# Altium schematic sheet 08)Battery_Charger.SchDoc(08)Battery_Charger)
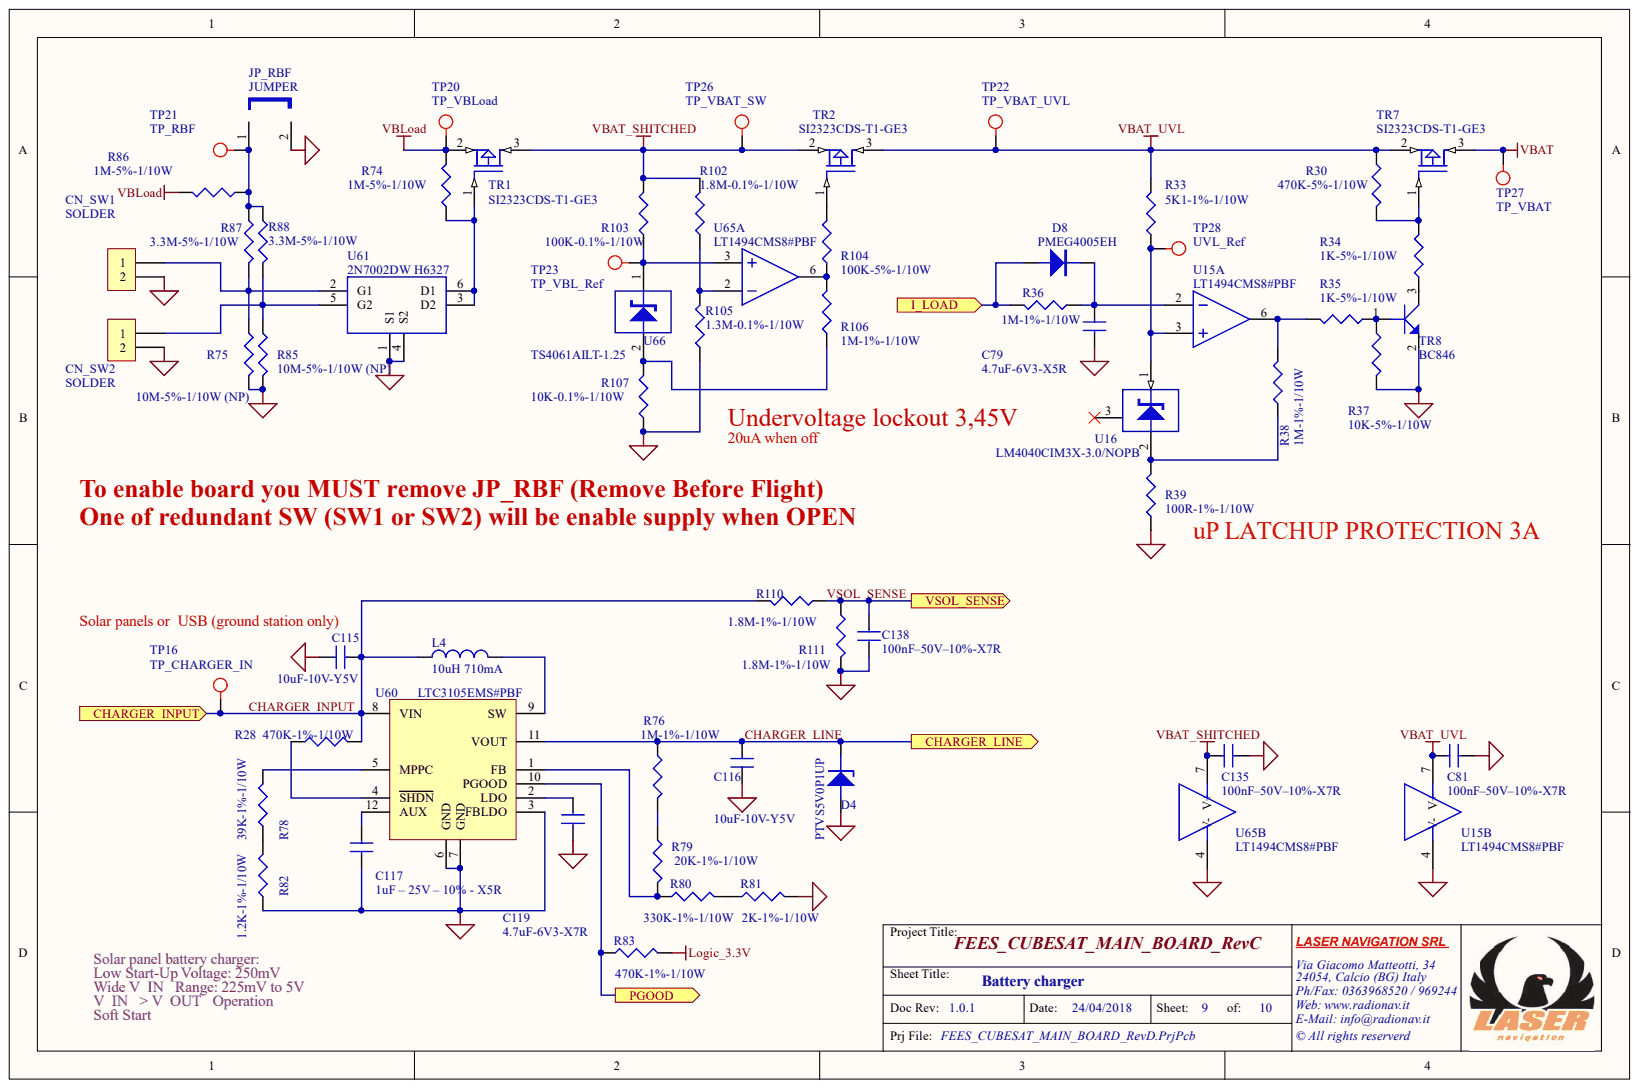
[diagram p0: 08)Battery_Charger.SchDoc]

## Parts
C79  4.7uF-6V3-X5R  [0805_CAP]
C81  100nF–50V–10%-X7R  [0603_CAP]
C115  10uF-10V-Y5V  [0805_CAP]
C116  10uF-10V-Y5V  [0805_CAP]
C117  1uF – 25V – 10% - X5R  [0603_CAP]
C119  4.7uF-6V3-X7R  [0603_CAP]
C135  100nF–50V–10%-X7R  [0603_CAP]
C138  100nF–50V–10%-X7R  [0603_CAP]
CN_SW1  SOLDER  [STRIPM1X2V]
CN_SW2  SOLDER  [STRIPM1X2V]
D4  PTVS5V0P1UP  [SOD128]
D8  PMEG4005EH  [SOD123]
JP_RBF  JUMPER  [TSW-101-08-G-D-RA]
L4  10uH 710mA  [LQH3NP]
R28  470K-1%-1/10W  [0603_RES]
R30  470K-5%-1/10W  [0603_RES]
R33  5K1-1%-1/10W  [0603_RES]
R34  1K-5%-1/10W  [0603_RES]
R35  1K-5%-1/10W  [0603_RES]
R36  1M-1%-1/10W  [0603_RES]
R37  10K-5%-1/10W  [0603_RES]
R38  1M-1%-1/10W  [0603_RES]
R39  100R-1%-1/10W  [0603_RES]
R74  1M-5%-1/10W  [0603_RES]
R75  10M-5%-1/10W (NP)  [0603_RES]
R76  1M-1%-1/10W  [0603_RES]
R78  39K-1%-1/10W  [0603_RES]
R79  20K-1%-1/10W  [0603_RES]
R80  330K-1%-1/10W  [0603_RES]
R81  2K-1%-1/10W  [0603_RES]
R82  1.2K-1%-1/10W  [0603_RES]
R83  470K-1%-1/10W  [0603_RES]
R85  10M-5%-1/10W (NP)  [0603_RES]
R86  1M-5%-1/10W  [0603_RES]
R87  3.3M-5%-1/10W  [0603_RES]
R88  3.3M-5%-1/10W  [0603_RES]
R102  1.8M-0.1%-1/10W  [0805_RES]
R103  100K-0.1%-1/10W  [0603_RES]
R104  100K-5%-1/10W  [0603_RES]
R105  1.3M-0.1%-1/10W  [0805_RES]
R106  1M-1%-1/10W  [0603_RES]
R107  10K-0.1%-1/10W  [0603_RES]
R110  1.8M-1%-1/10W  [0603_RES]
R111  1.8M-1%-1/10W  [0603_RES]
TP16  TP_CHARGER_IN  [TP_SMD_PAD_1_5MM]
TP20  TP_VBLoad  [TP_SMD_PAD_1_5MM]
TP21  TP_RBF  [TP_SMD_PAD_1_5MM]
TP22  TP_VBAT_UVL  [TP_SMD_PAD_1_5MM]
TP23  TP_VBL_Ref  [TP_SMD_PAD_1_5MM]
TP26  TP_VBAT_SW  [TP_SMD_PAD_1_5MM]
TP27  TP_VBAT  [TP_SMD_PAD_1_5MM]
TP28  UVL_Ref  [TP_SMD_PAD_1_5MM]
TR1  SI2323CDS-T1-GE3  [SOT23]
TR2  SI2323CDS-T1-GE3  [SOT23]
TR7  SI2323CDS-T1-GE3  [SOT23]
TR8  BC846  [BC_SOT32]
U15  LT1494CMS8#PBF  [MSOP8]  (parts A,B)
U16  LM4040CIM3X-3.0/NOPB  [SOT23]
U60  LTC3105EMS#PBF  [TSSOP12_MSOP]
U61  2N7002DW H6327  [SOT363]
U65  LT1494CMS8#PBF  [MSOP8]  (parts A,B)
U66  TS4061AILT-1.25  [SOT23]

## Ports
CHARGER_INPUT, CHARGER_LINE, I_LOAD, PGOOD, VSOL_SENSE

## Nets
GND: C79.1 C81.1 C115.1 C116.2 C117.2 C119.2 C135.1 C138.1 CN_SW1.2 CN_SW2.2 D4.1 JP_RBF.2 R37.2 R39.1 R75.2 R81.2 R82.1 R85.2 R105.1 R107.1 R111.2 TR8.2 U15.4(V-) U60.3(FBLDO) U60.6(GND) U60.7(GND) U61.1(S1) U61.4(S2) U65.4(V-)
LOGIC_3.3V: R83.2
VBAT: TP27.1 TR7.3
VBAT_SHITCHED: C135.2 R102.2 R103.2 TP26.1 TR1.3 TR2.2 U65.7(V+)
VBAT_UVL: C81.2 R30.1 R33.2 TP22.1 TR2.3 TR7.2 U15.7(V+)
VBLOAD: R74.2 R86.2 TP20.1 TR1.2
CHARGER_INPUT: C115.2 L4.1 R28.2 R110.2 TP16.1 U60.8(VIN) ; port CHARGER_INPUT
CHARGER_LINE: C116.1 D4.2 R76.1 U60.11(VOUT) ; port CHARGER_LINE
I_LOAD: D8.1 R36.2 ; port I_LOAD
PGOOD: R83.1 U60.10(PGOOD) ; port PGOOD
VSOL_SENSE: C138.2 R110.1 R111.1 ; port VSOL_SENSE
NetC79_2: C79.2 D8.2 R36.1 U15.2
NetC117_1: C117.1 U60.12(AUX)
NetC119_1: C119.1 U60.2(LDO)
NetCN_SW1_1: CN_SW1.1 R75.1 R87.2 U61.2(G1)
NetCN_SW2_1: CN_SW2.1 R85.1 R88.2 U61.5(G2)
NetJP_RBF_1: JP_RBF.1 R86.1 R87.1 R88.1 TP21.1
NetL4_2: L4.2 U60.9(SW)
NetR28_1: R28.1 U60.4(SHDN)
NetR30_2: R30.2 R34.2 TR7.1
NetR33_1: R33.1 TP28.1 U15.3 U16.1
NetR34_1: R34.1 TR8.3
NetR35_1: R35.1 R37.1 TR8.1
NetR35_2: R35.2 R38.2 U15.6
NetR38_1: R38.1 R39.2 U16.2
NetR74_1: R74.1 TR1.1 U61.3(D2) U61.6(D1)
NetR76_2: R76.2 R79.1
NetR78_1: R78.1 R82.2
NetR78_2: R78.2 U60.5(MPPC)
NetR79_2: R79.2 R80.1 U60.1(FB)
NetR80_2: R80.2 R81.1
NetR102_1: R102.1 R105.2 U65.2
NetR103_1: R103.1 TP23.1 U65.3 U66.1
NetR104_1: R104.1 R106.2 U65.6
NetR104_2: R104.2 TR2.1
NetR106_1: R106.1 R107.2 U66.2

Not connected: U16 3

## Library data of the parts
C79 = CAP: Non polarized capacitor; 1.Part Number: CC0805KRX5R5BB475; 2.Manufacturer: Yageo; 3.Description: CAP CER 4.7UF 6.3V X5R 0805; 5.Mounting: Y; 4.Supplier: Digikey 311-3422-1-ND; Component Kind: Standard; Library Name: User Sch Library.SchLib
C81 C135 = CAP: Non polarized capacitor; Component Kind: Standard; Library Name: User Sch Library.SchLib
C115 C116 = CAP: Non polarized capacitor; 1.Part Number: C0805C106Z8VACTU; 2.Manufacturer: Kemet; 3.Description: CAP CER 10UF 10V -20%/+80% Y5V 0805; 5.Mounting: Y; 4.Supplier: Mouser 80-C0805C106Z8V  // Digikey 399-8015-1-ND; Component Kind: Standard; Library Name: User Sch Library.SchLib
C117 = CAP: Non polarized capacitor; 1.Part Number: CL10A105KA8NNNC; 2.Manufacturer: Samsung; 3.Description: CAP CER 1UF 25V 10% X5R 0603; 5.Mounting: Y; 4.Supplier: Digikey 1276-1102-1-ND; Component Kind: Standard; Library Name: User Sch Library.SchLib
C119 = CAP: Non polarized capacitor; 1.Part Number: CL10B475KQ8NQNC; 2.Manufacturer: Samsung; 3.Description: CAP CER 4.7UF 6.3V X7R 0603; 5.Mounting: Y; 4.Supplier: Digikey 1276-2087-1-ND; Component Kind: Standard; Library Name: User Sch Library.SchLib
C138 = CAP: Non polarized capacitor; 5.Mounting: Y; Component Kind: Standard; Library Name: User Sch Library.SchLib
CN_SW1 CN_SW2 = CON2: Connector; 5.Mounting: SOLDERING; Component Kind: Standard; Library Name: User Sch Library.SchLib
D4 = ZENER4: Zener Diode; 1.Part Number: PTVS5V0P1UP,115; 2.Manufacturer: NXP Semiconductors; 3.Description: TVS DIODE 5VWM 9.2VC SOD128; 5.Mounting: Y; 4.Supplier: Digikey 568-5334-1-ND; Component Kind: Standard; Library Name: User Sch Library.SchLib
D8 = DIODE_SS34: Diode; 1.Part Number: PMEG4005EH,115; 2.Manufacturer: NXP Semiconductors; 3.Description: IODE SCHOTTKY 40V 500MA SOD123F; 5.Mounting: Y; 4.Supplier: Farnell 1510688RL // Digikey 568-4132-1-ND; Component Kind: Standard; Library Name: User Sch Library.SchLib
JP_RBF = JUMPER: 2.Manufacturer: Samtec Inc.; 3.Description: CONN HEADER 2POS .100" DL R/A AU; 5.Mounting: Y; 1.Part Number: TSW-101-08-G-D-RA; 4.Supplier: Digikey  SAM1037-01-ND; Component Kind: Standard; Description: Jumper; Library Name: User Sch Library.SchLib
L4 = INDUCTOR: 1.Part Number: LQH3NPN100NJ0L; 2.Manufacturer: Murata; 3.Description: FIXED IND 10UH 710MA 300 MOHM; 5.Mounting: Y; 4.Supplier: digikey 490-5348-1-ND // mouser 81-LQH3NPN100NJ0L; Component Kind: Standard; Description: Inductor; Library Name: User Sch Library.SchLib
R28 R30 R33-R35 R39 R74 R78-R83 R86-R88 R104 = R: Component Kind: Standard; Library Name: User Sch Library.SchLib
R36-R38 R76 R106 = R: 5.Mounting: Y; Component Kind: Standard; Library Name: User Sch Library.SchLib
R75 R85 = R: 5.Mounting: not mounted; Component Kind: Standard; Library Name: User Sch Library.SchLib
R102 = R: 1.Part Number: CPF0805B1M8E; 2.Manufacturer: TE Connectivity Passive Product; 3.Description: RES SMD 1.8M OHM 0.1% 1/10W 0805; 5.Mounting: Y; 4.Supplier: Digikey A119973CT-ND; Component Kind: Standard; Library Name: User Sch Library.SchLib
R103 = R: 1.Part Number: AT0603BRD07100KL; 2.Manufacturer: Yageo; 3.Description: RES SMD 100K OHM 0.1% 1/10W 0603; 5.Mounting: Y; 4.Supplier: Digikey YAG2107CT-ND; Component Kind: Standard; Library Name: User Sch Library.SchLib
R105 = R: 1.Part Number: CPF0805B1M3E1; 2.Manufacturer: TE Connectivity Passive Product; 3.Description: RES SMD 1.3M OHM 0.1% 1/10W 0805; 5.Mounting: Y; 4.Supplier: Digikey A103798CT-ND; Component Kind: Standard; Library Name: User Sch Library.SchLib
R107 = R: 1.Part Number: AT0603BRD0710KL; 2.Manufacturer: Yageo; 3.Description: RES SMD 10K OHM 0.1% 1/10W 0603; 5.Mounting: Y; 4.Supplier: Digikey YAG2108CT-ND; Component Kind: Standard; Library Name: User Sch Library.SchLib
R110 R111 = R: 1.Part Number: RC0603FR-071M8L; 2.Manufacturer: Yageo; 3.Description: RES SMD 1.8M OHM 1% 1/10W 0603; 5.Mounting: Y; 4.Supplier: Digikey YAG3328CT-ND; Component Kind: Standard; Library Name: User Sch Library.SchLib
TP16 TP20-TP23 TP26-TP28 = TP: Test Point; Component Kind: Standard; Library Name: User Sch Library.SchLib
TR1 TR2 TR7 = MOSFET P_1: 2.Manufacturer: Vishay Siliconix; 3.Description: MOSFET P-CH 20V 6A SOT-23; 5.Mounting: Y; 1.Part Number: SI2323CDS-T1-GE3; 4.Supplier: Digikey  SI2323CDS-T1-GE3CT-ND; Component Kind: Standard; Library Name: User Sch Library.SchLib
TR8 = BJT_NPN: NPN Bipolar Transistor; 1.Part Number: BC846A,215; 2.Manufacturer: NXP Semiconductors; 3.Description: TRANS NPN 65V 0.1A SOT23; 5.Mounting: Y; 4.Supplier: Digikey 1727-4239-1-ND; Component Kind: Standard; Library Name: User Sch Library.SchLib
U15 U65 = LT1494: Low power dual operational amplifiers; 2.Manufacturer: Linear Technology; 3.Description: General Purpose Amplifier 1 Circuit Rail-to-Rail 8-MSOP; 5.Mounting: Y; 1.Part Number: LT1494CMS8#PBF; 4.Supplier: Digikey LT1494CMS8#PBF-ND; Component Kind: Standard; Library Name: User Sch Library.SchLib
U16 = LM4040: Voltage Reference; 2.Manufacturer: Texas Instruments; 3.Description: IC VREF SHUNT 3V SOT23-3; 5.Mounting: Y; 1.Part Number: LM4040CIM3X-3.0/NOPB; 4.Supplier: Digikey LM4040CIM3X-3.0/NOPBCT-ND; Component Kind: Standard; Library Name: User Sch Library.SchLib
U60 = LTC3105EDD#PBF: IC CONV DC/DC; 2.Manufacturer: Linear Technology; 3.Description: IC CONV DC/DC 400MA HIEFF 12MSOP; 5.Mounting: Y; 1.Part Number: LTC3105EMS#PBF; 4.Supplier: Digikey LTC3105EMS#PBF-ND; Component Kind: Standard; Library Name: User Sch Library.SchLib
U61 = 2N7002: 2.Manufacturer: Infineon Technologies; 3.Description: MOSFET 2N-CH 60V 0.3A SOT363; 5.Mounting: Y; 1.Part Number: 2N7002DW H6327; 4.Supplier: Mouser 726-2N7002DWH6327 // Digikey 2N7002DW H6327CT-ND; Component Kind: Standard; Library Name: User Sch Library.SchLib
U66 = TS4061: Voltage Reference; 2.Manufacturer: STMicroelectronics; 3.Description: Shunt Voltage Reference IC ±0.1% 15mA SOT-23-3; 5.Mounting: Y; 1.Part Number: TS4061AILT-1.25; 4.Supplier: Digikey 497-15222-1-ND; Component Kind: Standard; Library Name: User Sch Library.SchLib
